# Altium schematic sheet arm.SchDoc (continued)

## Library data of the parts
ARM_JTAG = 20021121-00010C4LF: Conn Unshrouded Header HDR 10 POS 1.27mm Solder ST Top Entry SMD Minitek127® T/R; Manufacturer 1: Amphenol ICC / FCI; Component Kind: Standard; ComponentLink1Description: https://datasheet.ciiva.com/11749/0900766b8118d62a-11749057.pdf?src-supplier=RSComponents; ComponentLink1URL: https://datasheet.ciiva.com/11749/0900766b8118d62a-11749057.pdf?src-supplier=RSComponents; Manufacturer Part Number 1: 20021121-00010C4LF; Pricing 1: 1=0,91000, 10=0,76900, 100=0,69070 (USD); RoHS: No; Stock 1: 0; Supplier 1: Digi-Key; Supplier Part Number 1: 609-3695-1-ND; Library Name: conectors.SchLib
C14 C18-C21 C25-C30 C32 C33 C45 = CAP_CC0805KRX7R8BB104_YAGEO: CAPACITOR, 0805, 100NF, 25V, REEL 4000; Manufacturer 1: Yageo; Dielectric: X7R; Insulation Resistance: 100GR; Material: Ceramic; Tolerance: 10%; Case/Package: 0805; Case Code (Imperial): 0805; Case Code (Metric): 2012; Component Kind: Standard; ComponentLink1Description: https://datasheet.ciiva.com/8034/70765-8034031.pdf?src-supplier=Element14; ComponentLink1URL: https://datasheet.ciiva.com/8034/70765-8034031.pdf?src-supplier=Element14; ComponentLink2Description: https://datasheet.ciiva.com/28450/1489800-28450789.pdf?src-supplier=Element14; ComponentLink2URL: https://datasheet.ciiva.com/28450/1489800-28450789.pdf?src-supplier=Element14; ComponentLink3Description: https://datasheet.ciiva.com/46430/1850775-46430166.pdf?src-supplier=Element14; ComponentLink3URL: https://datasheet.ciiva.com/46430/1850775-46430166.pdf?src-supplier=Element14; ComponentLink4Description: https://datasheet.ciiva.com/145/mlccorderingcode-145008.pdf?src-supplier=Digi-Key; ComponentLink4URL: https://datasheet.ciiva.com/145/mlccorderingcode-145008.pdf?src-supplier=Digi-Key; ComponentLink5Description: https://datasheet.ciiva.com/145/mlccorderingcode-145007.pdf?src-supplier=Digi-Key; ComponentLink5URL: https://datasheet.ciiva.com/145/mlccorderingcode-145007.pdf?src-supplier=Digi-Key; ComponentLink6Description: https://datasheet.ciiva.com/145/mlccorderingcode-145009.pdf?src-supplier=Digi-Key; ComponentLink6URL: https://datasheet.ciiva.com/145/mlccorderingcode-145009.pdf?src-supplier=Digi-Key; ComponentLink7Description: https://datasheet.ciiva.com/8034/1784427-8034030.pdf?src-supplier=Element14; ComponentLink7URL: https://datasheet.ciiva.com/8034/1784427-8034030.pdf?src-supplier=Element14; ComponentLink8Description: https://datasheet.ciiva.com/8034/1784427-8034029.pdf?src-supplier=Element14; ComponentLink8URL: https://datasheet.ciiva.com/8034/1784427-8034029.pdf?src-supplier=Element14; ComponentLink9Description: https://datasheet.ciiva.com/15189/getdatasheetpartid-330244-15189223.pdf?src-supplier=Verical; ComponentLink9URL: https://datasheet.ciiva.com/15189/getdatasheetpartid-330244-15189223.pdf?src-supplier=Verical; ComponentLink10Description: https://datasheet.ciiva.com/16625/upy-gphc-x7r-6-3v-to-50v-8-16625546.pdf?src-supplier=Avnet+Europe; ComponentLink10URL: https://datasheet.ciiva.com/16625/upy-gphc-x7r-6-3v-to-50v-8-16625546.pdf?src-supplier=Avnet+Europe; Depth: 1.25mm; Halogen Free: Halogen Free; Height: 0.85mm; Lead Free: Lead Free; Length: 2mm; Library Name: Cap.SchLib; Manufacturer Part Number 1: CC0805KRX7R8BB104; Max Operating Temperature: 125°C; Min Operating Temperature: -55°C; Mount: Surface Mount; Number of Pins: 2; Package Quantity: 1; Packaging: Tape and Reel; Pricing 1: 1=0,12000, 10=0,08800, 50=0,04980, 100=0,04130, 500=0,02894, 1000=0,02396 (USD); Radiation Hardening: No; REACH SVHC: No SVHC; Resistor Type: Ceramic; RoHS: Yes; RoHS Compliant: Yes; Series: CC; Stock 1: 278639; Supplier 1: Digi-Key; Supplier Part Number 1: 311-1141-1-ND; Termination: SMD/SMT; Thickness: 0.033inch; Voltage Rating (DC): 25V; Width: 0.049inch
C15 C22 C23 C31 = CAP_C0805X150J5GACTU_KEMET: Multilayer Ceramic Capacitors MLCC - SMD/SMT 50volts 15pF 5% C0G; Manufacturer 1: KEMET; Case/Package: 0805; Case Code (Imperial): 0805; Case Code (Metric): 2012; Component Kind: Standard; ComponentLink1Description: http://www.mouser.com/ds/2/212/KEM_C1062_C0G_FT-CAP_SMD-1101746.pdf?src-supplier=Mouser; ComponentLink1URL: http://www.mouser.com/ds/2/212/KEM_C1062_C0G_FT-CAP_SMD-1101746.pdf?src-supplier=Mouser; ComponentLink2Description: http://www.kemet.com/kemet/web/homepage/kechome.nsf/file/KEM_C1062_C0G_FT-CAP_SMD.pdf/$file/KEM_C1062_C0G_FT-CAP_SMD.pdf?src-supplier=Avnet; ComponentLink2URL: http://www.kemet.com/kemet/web/homepage/kechome.nsf/file/KEM_C1062_C0G_FT-CAP_SMD.pdf/$file/KEM_C1062_C0G_FT-CAP_SMD.pdf?src-supplier=Avnet; ComponentLink3Description: https://search.kemet.com/component-edge/download/specsheet/C0805X150J5GACTU.pdf?src-supplier=Digi-Key; ComponentLink3URL: https://search.kemet.com/component-edge/download/specsheet/C0805X150J5GACTU.pdf?src-supplier=Digi-Key; Construction: Flat; Depth: 1.25mm; Dielectric: C0G; Dielectric Material: Ceramic; Features: Soft Termination; Height: 0.78mm; Lead Free: Lead Free; Length: 0.083inch; Library Name: Cap.SchLib; Manufacturer Part Number 1: C0805X150J5GACTU; Max Operating Temperature: 125°C; Min Operating Temperature: -55°C; Mount: Surface Mount; Package Quantity: 4000; Packaging: Tape and Reel; Pricing 1: 1=0,33000, 10=0,23100, 50=0,16160, 100=0,13860, 500=0,10396, 1000=0,08778 (USD); Radiation Hardening: No; RoHS: Yes; RoHS Compliant: Yes; Series: FT-CAP; Stock 1: 2348; Supplier 1: Digi-Key; Supplier Part Number 1: 399-7428-1-ND; Termination: SMD/SMT; Thickness: 0.035inch; Tolerance: 5%; Voltage Rating (DC): 50V; Width: 1.25mm
C16 C17 C24 C42 = CAP_CL21A106KOCL3RC: CAP CER 10UF 16V X5R 0805; Manufacturer 1: Samsung; Tolerance: 10%; Case/Package: 0805; Case Code (Imperial): 0805; Case Code (Metric): 2012; Component Kind: Standard; ComponentLink1Description: https://datasheet.ciiva.com/25796/cl21a106kocl3rc-25796224.pdf?src-supplier=Digi-Key; ComponentLink1URL: https://datasheet.ciiva.com/25796/cl21a106kocl3rc-25796224.pdf?src-supplier=Digi-Key; Length: 0.079inch; Library Name: Cap.SchLib; Manufacturer Part Number 1: CL21A106KOCL3RC; Max Operating Temperature: 85°C; Min Operating Temperature: -55°C; Mount: Surface Mount; Package Quantity: 1; Packaging: Tape and Reel; RoHS: Yes; RoHS Compliant: Yes; Series: CL; Stock 1: 0; Supplier 1: Digi-Key; Supplier Part Number 1: 1276-2398-2-ND; Thickness: 0.037inch; Width: 0.049inch
C34 C35 = CAP_CC0805KKX7R7BB105_YAGEO: YAGEO   CC0805KKX7R7BB105   CERAMIC CAPACITOR, 1UF, 16V, X7R, 10%, 0805; Case/Package: 0805; Case Code (Imperial): 0805; Case Code (Metric): 2012; Component Kind: Standard; ComponentLink1Description: https://datasheet.ciiva.com/144/mlccorderingcode-144902.pdf?src-supplier=Digi-Key; ComponentLink1URL: https://datasheet.ciiva.com/144/mlccorderingcode-144902.pdf?src-supplier=Digi-Key; ComponentLink2Description: https://datasheet.ciiva.com/144/mlccorderingcode-144903.pdf?src-supplier=Digi-Key; ComponentLink2URL: https://datasheet.ciiva.com/144/mlccorderingcode-144903.pdf?src-supplier=Digi-Key; ComponentLink3Description: https://datasheet.ciiva.com/144/mlccorderingcode-144904.pdf?src-supplier=Digi-Key; ComponentLink3URL: https://datasheet.ciiva.com/144/mlccorderingcode-144904.pdf?src-supplier=Digi-Key; ComponentLink4Description: https://datasheet.ciiva.com/11464/upy-gphc-x7r-6-3v-to-50v-8-11464750.pdf?src-supplier=Avnet; ComponentLink4URL: https://datasheet.ciiva.com/11464/upy-gphc-x7r-6-3v-to-50v-8-11464750.pdf?src-supplier=Avnet; ComponentLink5Description: https://datasheet.ciiva.com/13173/upy-gp-np0-16v-to-50v-8-3714-13173254.pdf?src-supplier=Mouser; ComponentLink5URL: https://datasheet.ciiva.com/13173/upy-gp-np0-16v-to-50v-8-3714-13173254.pdf?src-supplier=Mouser; ComponentLink6Description: https://datasheet.ciiva.com/7978/1489800-7978201.pdf?src-supplier=Element14; ComponentLink6URL: https://datasheet.ciiva.com/7978/1489800-7978201.pdf?src-supplier=Element14; ComponentLink7Description: https://datasheet.ciiva.com/28450/1489800-28450750.pdf?src-supplier=Element14; ComponentLink7URL: https://datasheet.ciiva.com/28450/1489800-28450750.pdf?src-supplier=Element14; ComponentLink8Description: https://datasheet.ciiva.com/15189/getdatasheetpartid-251802-15189206.pdf?src-supplier=Verical; ComponentLink8URL: https://datasheet.ciiva.com/15189/getdatasheetpartid-251802-15189206.pdf?src-supplier=Verical; Depth: 1.25mm; Dielectric: X7R; Halogen Free: Halogen Free; Lead Free: Lead Free; Length: 2mm; Library Name: Cap.SchLib; Manufacturer 1: Yageo; Manufacturer Part Number 1: CC0805KKX7R7BB105; Max Operating Temperature: 125°C; Min Operating Temperature: -55°C; Mount: Surface Mount; Package Quantity: 1; Packaging: Tape and Reel; Pricing 1: 1=0,16000, 10=0,11700, 50=0,06640, 100=0,05500, 500=0,03858, 1000=0,03194 (USD); Radiation Hardening: No; REACH SVHC: No SVHC; RoHS: Yes; RoHS Compliant: Yes; Series: CC; Stock 1: 516899; Supplier 1: Digi-Key; Supplier Part Number 1: 311-1365-1-ND; Termination: SMD/SMT; Thickness: 0.049inch; Tolerance: 10%; Voltage Rating (DC): 16V; Width: 0.049inch
D3 = 150080RS75000: SMD LED red 2.4 V 0805, 150080RS75000, Würth Elektronik; Manufacturer 1: Wurth Electronics; Dominant Wavelength: 625nm; Forward Current: 30mA; Forward Voltage: 2V; Lens Style: Clear,Rectangular; Luminous Intensity: 150mcd; Test Current: 20mA; Viewing Angle: 140°; Case/Package: 0805; Case Code (Imperial): 0805; Case Code (Metric): 2012; Color: Red; Component Kind: Standard; ComponentLink1Description: https://datasheet.ciiva.com/22656/150080rs75000-22656961.pdf?src-supplier=Element14; ComponentLink1URL: https://datasheet.ciiva.com/22656/150080rs75000-22656961.pdf?src-supplier=Element14; ComponentLink2Description: https://datasheet.ciiva.com/29279/759435-da-01-en-chipled-0805-w-kl-rot-150080rs75000-29279032.pdf?src-supplier=Conrad; ComponentLink2URL: https://datasheet.ciiva.com/29279/759435-da-01-en-chipled-0805-w-kl-rot-150080rs75000-29279032.pdf?src-supplier=Conrad; Height: 0.7mm; Length: 2mm; Library Name: diode.SchLib; Manufacturer Part Number 1: 150080RS75000; Max Operating Temperature: 85°C; Min Operating Temperature: -40°C; Mount: Surface Mount; Package Quantity: 4000; Packaging: Tape and Reel; Pricing 1: 1=0,18000, 50=0,17100, 100=0,14700, 500=0,12700 (USD); REACH SVHC: Unknown; RoHS: Yes; RoHS Compliant: Yes; Stock 1: 26828; Supplier 1: Digi-Key; Supplier Part Number 1: 732-4984-1-ND; Wavelength: 625nm; Width: 1.25mm
D4 D5 = DB2J20900L: PANASONIC - DB2J20900L - Schottky Rectifier, 20 V, 500 mA, Single, SOD-323F, 2 Pins, 500 mV; Manufacturer 1: Panasonic SSG; Average Rectified Current: 500mA; Forward Voltage: 500mV; Max Forward Surge Current (Ifsm): 3A; Max Repetitive Reverse Voltage (Vrrm): 20V; Max Reverse Current: 30uA; Reverse Recovery Time: 2.4ns; Component Kind: Standard; ComponentLink1Description: https://datasheet.ciiva.com/7964/db2j209-bed-7964194.pdf?src-supplier=Element14; ComponentLink1URL: https://datasheet.ciiva.com/7964/db2j209-bed-7964194.pdf?src-supplier=Element14; ComponentLink2Description: https://datasheet.ciiva.com/22650/db2j209-bed-22650026.pdf?src-supplier=Farnell; ComponentLink2URL: https://datasheet.ciiva.com/22650/db2j209-bed-22650026.pdf?src-supplier=Farnell; ComponentLink3Description: https://datasheet.ciiva.com/22659/1912053-22659242.pdf?src-supplier=Element14; ComponentLink3URL: https://datasheet.ciiva.com/22659/1912053-22659242.pdf?src-supplier=Element14; Contact Plating: Tin; Element Configuration: Single; Forward Current: 500mA; Height: 0.7mm; Lead Free: Lead Free; Length: 1.7mm; Library Name: diode.SchLib; Manufacturer Part Number 1: DB2J20900L; Max Operating Temperature: 125°C; Max Reverse Voltage (DC): 20V; Min Operating Temperature: -55°C; Mount: Surface Mount; Number of Pins: 2; Package Quantity: 1; Packaging: Tape and Reel; Peak Non-Repetitive Surge Current: 3A; Pricing 1: 1=0,17400, 100=0,16600, 200=0,15100, 400=0,13600, 1000=0,12100 (USD); REACH SVHC: Unknown; RoHS: Yes; RoHS Compliant: Yes; Stock 1: 0; Supplier 1: Allied Electronics; Supplier Part Number 1: 70358466; Width: 1.25mm
D6 = 150080VS75000: LED GREEN CLEAR 0805 SMD; Manufacturer 1: Wurth Electronics; Dominant Wavelength: 570nm; Forward Current: 30mA; Forward Voltage: 2V; Lens Style: Clear,Rectangular; Luminous Intensity: 40mcd; Test Current: 20mA; Viewing Angle: 140°; Case/Package: 0805; Case Code (Imperial): 0805; Case Code (Metric): 2012; Color: Green; Component Kind: Standard; ComponentLink1Description: https://datasheet.ciiva.com/22656/150080vs75000-22656966.pdf?src-supplier=Element14; ComponentLink1URL: https://datasheet.ciiva.com/22656/150080vs75000-22656966.pdf?src-supplier=Element14; ComponentLink2Description: https://datasheet.ciiva.com/29279/759437-da-01-en-chipled-0805-w-kl-h-gruen-150080vs7500-29279047.pdf?src-supplier=Conrad; ComponentLink2URL: https://datasheet.ciiva.com/29279/759437-da-01-en-chipled-0805-w-kl-h-gruen-150080vs7500-29279047.pdf?src-supplier=Conrad; ComponentLink3Description: https://datasheet.ciiva.com/29563/150080vs75000-29563018.pdf?src-supplier=Digi-Key; ComponentLink3URL: https://datasheet.ciiva.com/29563/150080vs75000-29563018.pdf?src-supplier=Digi-Key; Height: 0.7mm; Length: 2mm; Library Name: diode.SchLib; Manufacturer Part Number 1: 150080VS75000; Max Operating Temperature: 85°C; Min Operating Temperature: -40°C; Mount: Surface Mount; Package Quantity: 4000; Packaging: Tape and Reel; Pricing 1: 500=0,09600, 1000=0,09200, 2000=0,08700, 4000=0,08300 (GBP); REACH SVHC: Unknown; RoHS: Yes; Stock 1: 13900; Supplier 1: RSComponents; Supplier Part Number 1: 8154237P; Wavelength: 570nm; Width: 1.25mm
L1 = CM453232-100KL: Ind General Purpose Chip Wirewound 10uH 10% 2.52MHz 50Q-Factor Ferrite 250mA 1812 T/R; Manufacturer 1: Bourns; Current: 160mA; DC Resistance (DCR): 1.6R; Q Factor: 50; Self Resonant Frequency: 20MHz; Shielding: Unshielded; Case/Package: 1812; Case Code (Imperial): 1812; Case Code (Metric): 4532; Component Kind: Standard; ComponentLink1Description: https://datasheet.ciiva.com/27049/774461-27049974.pdf?src-supplier=Jameco; ComponentLink1URL: https://datasheet.ciiva.com/27049/774461-27049974.pdf?src-supplier=Jameco; ComponentLink2Description: https://datasheet.ciiva.com/11799/0900766b81218b74-11799714.pdf?src-supplier=RSComponents; ComponentLink2URL: https://datasheet.ciiva.com/11799/0900766b81218b74-11799714.pdf?src-supplier=RSComponents; ComponentLink3Description: https://datasheet.ciiva.com/29348/447189-da-01-en-induktivitaet-cm453232-100kl-10uh-250ma-29348045.pdf?src-supplier=Conrad; ComponentLink3URL: https://datasheet.ciiva.com/29348/447189-da-01-en-induktivitaet-cm453232-100kl-10uh-250ma-29348045.pdf?src-supplier=Conrad; ComponentLink4Description: https://datasheet.ciiva.com/3081/cm-3081727.pdf?src-supplier=Avnet; ComponentLink4URL: https://datasheet.ciiva.com/3081/cm-3081727.pdf?src-supplier=Avnet; ComponentLink5Description: https://datasheet.ciiva.com/6689/cm-6689143.pdf?src-supplier=Digi-Key; ComponentLink5URL: https://datasheet.ciiva.com/6689/cm-6689143.pdf?src-supplier=Digi-Key; ComponentLink6Description: https://datasheet.ciiva.com/6689/cm-6689144.pdf?src-supplier=Digi-Key; ComponentLink6URL: https://datasheet.ciiva.com/6689/cm-6689144.pdf?src-supplier=Digi-Key; ComponentLink7Description: https://datasheet.ciiva.com/6689/cm-6689145.pdf?src-supplier=Digi-Key; ComponentLink7URL: https://datasheet.ciiva.com/6689/cm-6689145.pdf?src-supplier=Digi-Key; ComponentLink8Description: https://datasheet.ciiva.com/4978/cm-4978555.pdf?src-supplier=Mouser; ComponentLink8URL: https://datasheet.ciiva.com/4978/cm-4978555.pdf?src-supplier=Mouser; ComponentLink9Description: https://datasheet.ciiva.com/25903/cm-25903528.pdf?src-supplier=Avnet+Europe; ComponentLink9URL: https://datasheet.ciiva.com/25903/cm-25903528.pdf?src-supplier=Avnet+Europe; ComponentLink10Description: https://datasheet.ciiva.com/14124/wfcm-dat-e-14124666.pdf?src-supplier=Distrelec; ComponentLink10URL: https://datasheet.ciiva.com/14124/wfcm-dat-e-14124666.pdf?src-supplier=Distrelec; ComponentLink11Description: https://datasheet.ciiva.com/15225/getdatasheetpartid-128072-15225413.pdf?src-supplier=Verical; ComponentLink11URL: https://datasheet.ciiva.com/15225/getdatasheetpartid-128072-15225413.pdf?src-supplier=Verical; ComponentLink12Description: https://datasheet.ciiva.com/7839/1481743-7839005.pdf?src-supplier=Element14; ComponentLink12URL: https://datasheet.ciiva.com/7839/1481743-7839005.pdf?src-supplier=Element14; ComponentLink13Description: https://datasheet.ciiva.com/7839/1481743-7839006.pdf?src-supplier=Element14; ComponentLink13URL: https://datasheet.ciiva.com/7839/1481743-7839006.pdf?src-supplier=Element14; Contact Plating: Tin; Core Material: Ferrite; DC Current: 250mA; Depth: 3.4mm; Height: 3mm; Height - Seated (Max): 0.134inch; Inductance: 10uH; Inductance Tolerance: 10%; Length: 4.8mm; Manufacturer Part Number 1: CM453232-100KL; Max DC Current: 250mA; Max Operating Temperature: 100°C; Military Standard: Not; Min Operating Temperature: -40°C; Mount: Surface Mount; Number of Pins: 2; Package Quantity: 500; Packaging: Tape and Reel; Pricing 1: 1=0,43000, 10=0,38100, 25=0,33320, 50=0,28540, 100=0,26170, 250=0,23788 (USD); Radiation Hardening: No; REACH SVHC: No SVHC; Resistor Type: Wirewound; RoHS: Yes; RoHS Compliant: Yes; Series: CM453232; Series Resistance: 1.6R; Stock 1: 1374; Supplier 1: Digi-Key; Supplier Part Number 1: CM453232-100KLCT-ND; Termination: SMD/SMT; Test Frequency: 2.52MHz; Tolerance: 10%; Width: 0.126inch; Library Name: inductores.SchLib
Q1 Q2 = MMBT2222ALT1G: Trans GP BJT NPN 40V 0.6A 3-Pin SOT-23 T/R; Manufacturer 1: ON Semiconductor; Collector-emitter Voltage-Max: 1V; Collector Base Voltage (VCBO): 75V; Current Rating: 600mA; Element Configuration: Single; Emitter Base Voltage (VEBO): 6V; Frequency: 300MHz; hFE Min: 100; Max Power Dissipation: 300mW; Number of Elements: 1; Type: General Purpose; Case/Package: SOT-23-3; Collector Emitter Breakdown Voltage: 40V; Collector Emitter Saturation Voltage: 1V; Collector Emitter Voltage (VCEO): 40V; Component Kind: Standard; ComponentLink1Description: https://datasheet.ciiva.com/16199/mmbt2222lt1-d-16199072.pdf?src-supplier=Future+Electronics; ComponentLink1URL: https://datasheet.ciiva.com/16199/mmbt2222lt1-d-16199072.pdf?src-supplier=Future+Electronics; ComponentLink2Description: https://datasheet.ciiva.com/15139/getdatasheetpartid-82691-15139077.pdf?src-supplier=Verical; ComponentLink2URL: https://datasheet.ciiva.com/15139/getdatasheetpartid-82691-15139077.pdf?src-supplier=Verical; ComponentLink3Description: https://datasheet.ciiva.com/976/mmbt2222lt1-d-976344.pdf?src-supplier=Digi-Key; ComponentLink3URL: https://datasheet.ciiva.com/976/mmbt2222lt1-d-976344.pdf?src-supplier=Digi-Key; ComponentLink4Description: https://datasheet.ciiva.com/976/mmbt2222lt1-d-976345.pdf?src-supplier=Digi-Key; ComponentLink4URL: https://datasheet.ciiva.com/976/mmbt2222lt1-d-976345.pdf?src-supplier=Digi-Key; ComponentLink5Description: https://datasheet.ciiva.com/976/mmbt2222lt1-d-976346.pdf?src-supplier=Digi-Key; ComponentLink5URL: https://datasheet.ciiva.com/976/mmbt2222lt1-d-976346.pdf?src-supplier=Digi-Key; ComponentLink6Description: https://datasheet.ciiva.com/11201/mmbt2222lt1-d-11201869.pdf?src-supplier=Avnet; ComponentLink6URL: https://datasheet.ciiva.com/11201/mmbt2222lt1-d-11201869.pdf?src-supplier=Avnet; ComponentLink7Description: https://datasheet.ciiva.com/25998/mmbt2222lt1-d-25998815.pdf?src-supplier=Avnet+Europe; ComponentLink7URL: https://datasheet.ciiva.com/25998/mmbt2222lt1-d-25998815.pdf?src-supplier=Avnet+Europe; ComponentLink8Description: https://datasheet.ciiva.com/7972/mmbt2222lt1-d-7972057.pdf?src-supplier=Element14; ComponentLink8URL: https://datasheet.ciiva.com/7972/mmbt2222lt1-d-7972057.pdf?src-supplier=Element14; ComponentLink9Description: https://datasheet.ciiva.com/7972/mmbt2222lt1-d-7972058.pdf?src-supplier=Element14; ComponentLink9URL: https://datasheet.ciiva.com/7972/mmbt2222lt1-d-7972058.pdf?src-supplier=Element14; ComponentLink10Description: https://datasheet.ciiva.com/14546/70099528-14546715.pdf?src-supplier=Allied+Electronics; ComponentLink10URL: https://datasheet.ciiva.com/14546/70099528-14546715.pdf?src-supplier=Allied+Electronics; Contact Plating: Tin,Matte; Gain Bandwidth Product: 300MHz; Height: 0.94mm; Lead Free: Lead Free; Length: 2.9mm; Library Name: others.SchLib; Manufacturer Part Number 1: MMBT2222ALT1G; Max Breakdown Voltage: 40V; Max Collector Current: 600mA; Max Frequency: 300MHz; Max Operating Temperature: 150°C; Min Operating Temperature: -55°C; Number of Pins: 3; Package Quantity: 1; Packaging: Tape and Reel; Polarity: NPN; Power Dissipation: 300mW; Pricing 1: 1=0,16000, 10=0,14800, 100=0,08030, 500=0,04944, 1000=0,03371 (USD); Radiation Hardening: No; REACH SVHC: No SVHC; RoHS: Yes; Series: -; Stock 1: 0; Supplier 1: Digi-Key; Supplier Part Number 1: MMBT2222ALT1GOSCT-ND; Transition Frequency: 300MHz; Voltage Rating (DC): 40V; Width: 1.3mm
R25 R33 = RC0805FR-07130RL: Res Thick Film smd 0805 130 Ohm 1% 0.125W(; Manufacturer 1: Yageo; Max Power Dissipation: 125mW; Temperature Coefficient: 100ppm/°C; Voltage Rating: 150V; Case/Package: 0805; Case Code (Imperial): 0805; Case Code (Metric): 2012; Component Kind: Standard; ComponentLink1Description: https://datasheet.ciiva.com/11808/0900766b8106beab-11808374.pdf?src-supplier=RSComponents; ComponentLink1URL: https://datasheet.ciiva.com/11808/0900766b8106beab-11808374.pdf?src-supplier=RSComponents; ComponentLink2Description: https://datasheet.ciiva.com/2457/pyu-r-int-thick-7-2457546.pdf?src-supplier=Digi-Key; ComponentLink2URL: https://datasheet.ciiva.com/2457/pyu-r-int-thick-7-2457546.pdf?src-supplier=Digi-Key; ComponentLink3Description: https://datasheet.ciiva.com/2457/pyu-r-int-thick-7-2457547.pdf?src-supplier=Digi-Key; ComponentLink3URL: https://datasheet.ciiva.com/2457/pyu-r-int-thick-7-2457547.pdf?src-supplier=Digi-Key; ComponentLink4Description: https://datasheet.ciiva.com/2457/pyu-r-int-thick-7-2457545.pdf?src-supplier=Digi-Key; ComponentLink4URL: https://datasheet.ciiva.com/2457/pyu-r-int-thick-7-2457545.pdf?src-supplier=Digi-Key; ComponentLink5Description: https://datasheet.ciiva.com/15189/getdatasheetpartid-326400-15189899.pdf?src-supplier=Verical; ComponentLink5URL: https://datasheet.ciiva.com/15189/getdatasheetpartid-326400-15189899.pdf?src-supplier=Verical; ComponentLink6Description: https://datasheet.ciiva.com/16746/rc0805-16746879.pdf?src-supplier=Future+Electronics; ComponentLink6URL: https://datasheet.ciiva.com/16746/rc0805-16746879.pdf?src-supplier=Future+Electronics; Construction: Rectangular; Depth: 1.25mm; Features: Moisture Resistant; Height: 0.5mm; Lead Free: Lead Free; Length: 2mm; Library Name: res.SchLib; Manufacturer Part Number 1: RC0805FR-07130RL; Max Operating Temperature: 155°C; Military Standard: Not; Min Operating Temperature: -55°C; Mount: Surface Mount; Number of Terminations: 2; Package Quantity: 1; Packaging: Tape and Reel; Power Rating: 125mW; Pricing 1: 1=0,10000, 10=0,04200, 100=0,01690, 1000=0,00759, 2500=0,00659 (USD); Resistor Type: Thick Film; RoHS: Yes; RoHS Compliant: Yes; Series: RC; Size Code: 0805; Stock 1: 289647; Supplier 1: Digi-Key; Supplier Part Number 1: 311-130CRCT-ND; Technology: THICK FILM; Termination: SMD/SMT; Tolerance: 1%; Voltage Rating (DC): 150V; Width: 0.049inch
R26-R29 R47 = AC0805JR-07100KL: Thick Film Resistors - SMD 0805  100K ohm 5% 1/8W; Manufacturer 1: Yageo; Max Power Dissipation: 125mW; Temperature Coefficient: 100ppm/°C; Voltage Rating: 150V; Case/Package: 0805; Case Code (Imperial): 0805; Case Code (Metric): 2012; Component Kind: Standard; ComponentLink1Description: https://datasheet.ciiva.com/12576/pyu-ac-51-rohs-l-2-12576604.pdf?src-supplier=Mouser; ComponentLink1URL: https://datasheet.ciiva.com/12576/pyu-ac-51-rohs-l-2-12576604.pdf?src-supplier=Mouser; Features: Moisture Resistant,Automotive AEC-Q200; Height: 0.024inch; Length: 0.079inch; Library Name: res.SchLib; Manufacturer Part Number 1: AC0805JR-07100KL; Max Operating Temperature: 155°C; Min Operating Temperature: -55°C; Number of Terminations: 2; Packaging: Tape and Reel; Power Rating: 125mW; Pricing 1: 1=0,10000, 10=0,04300, 100=0,01730, 1000=0,00777, 2500=0,00674 (USD); Resistor Type: Thick Film; RoHS: Yes; RoHS Compliant: Yes; Series: AC; Stock 1: 23; Supplier 1: Digi-Key; Supplier Part Number 1: YAG3756CT-ND; Termination: SMD/SMT; Tolerance: 5%; Weight: 0.000194oz; Width: 0.049inch
R30-R32 R34 R52-R55 = RC0805JR-0710KL: Res Thick Film smd 0805 10K Ohm 5% 1/8W; Manufacturer 1: Yageo; Max Power Dissipation: 125mW; Temperature Coefficient: 100ppm/°C; Voltage Rating: 150V; Case/Package: 0805; Case Code (Imperial): 0805; Case Code (Metric): 2012; Component Kind: Standard; ComponentLink1Description: https://datasheet.ciiva.com/7941/444164-7941870.pdf?src-supplier=Element14; ComponentLink1URL: https://datasheet.ciiva.com/7941/444164-7941870.pdf?src-supplier=Element14; ComponentLink2Description: https://datasheet.ciiva.com/7941/444164-7941871.pdf?src-supplier=Element14; ComponentLink2URL: https://datasheet.ciiva.com/7941/444164-7941871.pdf?src-supplier=Element14; ComponentLink3Description: https://datasheet.ciiva.com/7983/444164-7983765.pdf?src-supplier=Element14; ComponentLink3URL: https://datasheet.ciiva.com/7983/444164-7983765.pdf?src-supplier=Element14; Construction: Rectangular; Contact Plating: Tin,Matte; Depth: 1.25mm; Features: Moisture Resistant; Height: 0.5mm; Lead Free: Lead Free; Length: 2mm; Library Name: res.SchLib; Manufacturer Part Number 1: RC0805JR-0710KL; Max Operating Temperature: 155°C; Military Standard: Not; Min Operating Temperature: -55°C; Number of Terminations: 2; Packaging: Tape and Reel; Power Rating: 125mW; Pricing 1: 1=0,10000, 10=0,03600, 100=0,01450, 1000=0,00652, 2500=0,00566 (USD); Radiation Hardening: No; REACH SVHC: Unknown; Resistance Tolerance: 5%; Resistor Type: Thick Film; RoHS: Yes; RoHS Compliant: Yes; Series: RC; Size Code: 0805; Stock 1: 2124400; Supplier 1: Digi-Key; Supplier Part Number 1: 311-10KARCT-ND; Termination: SMD/SMT; Tolerance: 5%; Width: 1.25mm
R35 = RC1206FR-0710KL: Res Thick Film smd 1206 10K Ohm 1% 0.25W(1/4W); Manufacturer 1: Yageo; Max Power Dissipation: 250mW; Temperature Coefficient: 100ppm/°C; Voltage Rating: 200V; Case/Package: 1206; Case Code (Imperial): 1206; Case Code (Metric): 3216; Component Kind: Standard; ComponentLink1Description: https://datasheet.ciiva.com/7857/1692832-7857244.pdf?src-supplier=Element14; ComponentLink1URL: https://datasheet.ciiva.com/7857/1692832-7857244.pdf?src-supplier=Element14; ComponentLink2Description: https://datasheet.ciiva.com/7857/1692832-7857245.pdf?src-supplier=Element14; ComponentLink2URL: https://datasheet.ciiva.com/7857/1692832-7857245.pdf?src-supplier=Element14; ComponentLink3Description: https://datasheet.ciiva.com/46445/444357-46445703.pdf?src-supplier=Element14; ComponentLink3URL: https://datasheet.ciiva.com/46445/444357-46445703.pdf?src-supplier=Element14; Construction: Rectangular; Depth: 1.6mm; Features: Moisture Resistant; Height: 0.55mm; Lead Free: Lead Free; Length: 3.1mm; Library Name: res.SchLib; Manufacturer Part Number 1: RC1206FR-0710KL; Max Operating Temperature: 155°C; Military Standard: Not; Min Operating Temperature: -55°C; Number of Terminations: 2; Package Quantity: 5000; Packaging: Tape and Reel; Power Rating: 250mW; Pricing 1: 1=0,10000, 10=0,07400, 100=0,03010, 1000=0,01353, 2500=0,01174 (USD); REACH SVHC: Unknown; Resistance Tolerance: 1%; Resistor Type: Thick Film; RoHS: Yes; RoHS Compliant: Yes; Series: RC; Size Code: 1206; Stock 1: 845388; Supplier 1: Digi-Key; Supplier Part Number 1: 311-10.0KFRCT-ND; Termination: SMD/SMT; Tolerance: 1%; Width: 1.6mm
R36-R39 = RC1206JR-0768KL: Thick Film Resistors smd 1206(3216) 68K OHM 5% 250mW; Manufacturer 1: Yageo; Temperature Coefficient: 100ppm/°C; Voltage Rating: 200V; Case/Package: 1206; Case Code (Imperial): 1206; Case Code (Metric): 3216; Component Kind: Standard; ComponentLink1Description: https://datasheet.ciiva.com/7857/444357-7857527.pdf?src-supplier=Element14; ComponentLink1URL: https://datasheet.ciiva.com/7857/444357-7857527.pdf?src-supplier=Element14; ComponentLink2Description: https://datasheet.ciiva.com/7857/444357-7857528.pdf?src-supplier=Element14; ComponentLink2URL: https://datasheet.ciiva.com/7857/444357-7857528.pdf?src-supplier=Element14; Construction: Rectangular; Depth: 1.6mm; Features: Moisture Resistant; Lead Free: Lead Free; Length: 3.1mm; Library Name: res.SchLib; Manufacturer Part Number 1: RC1206JR-0768KL; Max Operating Temperature: 155°C; Max Power Dissipation: 250mW; Military Standard: Not; Min Operating Temperature: -55°C; Number of Terminations: 2; Packaging: Tape and Reel; Power Rating: 250mW; Pricing 1: 1=0,10000, 10=0,06300, 100=0,02580, 1000=0,01158, 2500=0,01005 (USD); REACH SVHC: No SVHC; Resistance Tolerance: 5%; Resistor Type: Thick Film; RoHS: Yes; RoHS Compliant: Yes; Series: RC; Size Code: 1206; Stock 1: 38664; Supplier 1: Digi-Key; Supplier Part Number 1: 311-68KERCT-ND; Termination: SMD/SMT; Tolerance: 5%
R40-R46 = RC1206JR-07100RL: Thick Film Resistors SMD 1206 (3264)  100 OHM 5% 250mW; Manufacturer 1: Yageo; Temperature Coefficient: 100ppm/°C; Voltage Rating: 200V; Case/Package: 1206; Case Code (Imperial): 1206; Case Code (Metric): 3216; Component Kind: Standard; ComponentLink1Description: https://datasheet.ciiva.com/29181/402427-da-01-en-smd-widerstand-chip1206-100-ohm-29181432.pdf?src-supplier=Conrad; ComponentLink1URL: https://datasheet.ciiva.com/29181/402427-da-01-en-smd-widerstand-chip1206-100-ohm-29181432.pdf?src-supplier=Conrad; ComponentLink2Description: https://datasheet.ciiva.com/2458/pyu-rc1206-51-rohs-l-4-2458710.pdf?src-supplier=Digi-Key; ComponentLink2URL: https://datasheet.ciiva.com/2458/pyu-rc1206-51-rohs-l-4-2458710.pdf?src-supplier=Digi-Key; ComponentLink3Description: https://datasheet.ciiva.com/2458/pyu-rc1206-51-rohs-l-4-2458711.pdf?src-supplier=Digi-Key; ComponentLink3URL: https://datasheet.ciiva.com/2458/pyu-rc1206-51-rohs-l-4-2458711.pdf?src-supplier=Digi-Key; ComponentLink4Description: https://datasheet.ciiva.com/2458/pyu-rc1206-51-rohs-l-4-2458712.pdf?src-supplier=Digi-Key; ComponentLink4URL: https://datasheet.ciiva.com/2458/pyu-rc1206-51-rohs-l-4-2458712.pdf?src-supplier=Digi-Key; ComponentLink5Description: https://datasheet.ciiva.com/16772/pyu-rc1206-51-rohs-l-4-16772235.pdf?src-supplier=Future+Electronics; ComponentLink5URL: https://datasheet.ciiva.com/16772/pyu-rc1206-51-rohs-l-4-16772235.pdf?src-supplier=Future+Electronics; Construction: Rectangular; Depth: 1.6mm; Height: 0.026inch; Lead Free: Lead Free; Length: 0.122inch; Library Name: res.SchLib; Manufacturer Part Number 1: RC1206JR-07100RL; Max Operating Temperature: 155°C; Max Power Dissipation: 250mW; Military Standard: Not; Min Operating Temperature: -55°C; Number of Terminations: 2; Package Quantity: 5000; Packaging: Tape and Reel; Power Rating: 250mW; Pricing 1: 1=0,10000, 10=0,06300, 100=0,02580, 1000=0,01158, 2500=0,01005 (USD); REACH SVHC: Unknown; Resistance Tolerance: 5%; Resistor Type: Thick Film; RoHS: Yes; RoHS Compliant: Yes; Series: RC1206; Size Code: 1206; Stock 1: 1229853; Supplier 1: Digi-Key; Supplier Part Number 1: 311-100ERCT-ND; Termination: SMD/SMT; Tolerance: 5%; Width: 0.063inch
R48 = RC1206JR-07180KL: RES SMD 1206 180K OHM 5% 1/4W; Manufacturer 1: Yageo; Max Power Dissipation: 250mW; Temperature Coefficient: 100ppm/°C; Voltage Rating: 200V; Case/Package: 1206; Case Code (Imperial): 1206; Case Code (Metric): 3216; Component Kind: Standard; ComponentLink1Description: https://datasheet.ciiva.com/29181/402818-da-01-en-smd-widerstand-chip1206-180-kohm-29181400.pdf?src-supplier=Conrad; ComponentLink1URL: https://datasheet.ciiva.com/29181/402818-da-01-en-smd-widerstand-chip1206-180-kohm-29181400.pdf?src-supplier=Conrad; ComponentLink2Description: https://datasheet.ciiva.com/2458/pyu-r-int-thick-7-2458890.pdf?src-supplier=Digi-Key; ComponentLink2URL: https://datasheet.ciiva.com/2458/pyu-r-int-thick-7-2458890.pdf?src-supplier=Digi-Key; ComponentLink3Description: https://datasheet.ciiva.com/2458/pyu-r-int-thick-7-2458891.pdf?src-supplier=Digi-Key; ComponentLink3URL: https://datasheet.ciiva.com/2458/pyu-r-int-thick-7-2458891.pdf?src-supplier=Digi-Key; ComponentLink4Description: https://datasheet.ciiva.com/2458/pyu-r-int-thick-7-2458892.pdf?src-supplier=Digi-Key; ComponentLink4URL: https://datasheet.ciiva.com/2458/pyu-r-int-thick-7-2458892.pdf?src-supplier=Digi-Key; ComponentLink5Description: https://datasheet.ciiva.com/17053/pyu-rc1206-51-rohs-l-4-17053351.pdf?src-supplier=Future+Electronics; ComponentLink5URL: https://datasheet.ciiva.com/17053/pyu-rc1206-51-rohs-l-4-17053351.pdf?src-supplier=Future+Electronics; Construction: Rectangular; Depth: 1.6mm; Features: Moisture Resistant; Height: 0.026inch; Lead Free: Lead Free; Length: 3.1mm; Library Name: res.SchLib; Manufacturer Part Number 1: RC1206JR-07180KL; Max Operating Temperature: 155°C; Military Standard: Not; Min Operating Temperature: -55°C; Number of Terminations: 2; Package Quantity: 1; Packaging: Tape and Reel; Power Rating: 250mW; Pricing 1: 10=0,03100, 25=0,02500, 50=0,01800, 100=0,01100 (USD); Resistor Type: Thick Film; RoHS: Yes; RoHS Compliant: Yes; Series: RC; Size Code: 1206; Stock 1: 23350; Supplier 1: Newark; Supplier Part Number 1: 82AH8580; Termination: SMD/SMT; Tolerance: 5%; Voltage Rating (DC): 200V; Width: 1.6mm
R49 R50 = RC0805JR-0722RL: Res Thick Film smd  0805 22 Ohm 5% 1/8W; Manufacturer 1: Yageo; Max Power Dissipation: 125mW; Temperature Coefficient: 100ppm/°C; Voltage Rating: 150V; Case/Package: 0805; Case Code (Imperial): 0805; Case Code (Metric): 2012; Component Kind: Standard; ComponentLink1Description: http://www.mouser.com/ds/2/447/Yageo_08112016_RC0805-968729.pdf?src-supplier=Mouser; ComponentLink1URL: http://www.mouser.com/ds/2/447/Yageo_08112016_RC0805-968729.pdf?src-supplier=Mouser; ComponentLink2Description: http://www.farnell.com/datasheets/2167052.pdf?src-supplier=Farnell; ComponentLink2URL: http://www.farnell.com/datasheets/2167052.pdf?src-supplier=Farnell; ComponentLink3Description: http://components.arrow.com/part/dataview/0323_00047573?src-supplier=Arrow+Europe; ComponentLink3URL: http://components.arrow.com/part/dataview/0323_00047573?src-supplier=Arrow+Europe; ComponentLink4Description: http://www.farnell.com/datasheets/444164.pdf?src-supplier=Farnell; ComponentLink4URL: http://www.farnell.com/datasheets/444164.pdf?src-supplier=Farnell; ComponentLink5Description: http://www.yageo.com/documents/recent/PYu-RC_Group_51_RoHS_L_10.pdf?src-supplier=Digi-Key; ComponentLink5URL: http://www.yageo.com/documents/recent/PYu-RC_Group_51_RoHS_L_10.pdf?src-supplier=Digi-Key; ComponentLink6Description: http://www.yageo.com/documents/recent/PYu-RC_Group_51_RoHS_L_9.pdf?src-supplier=Digi-Key; ComponentLink6URL: http://www.yageo.com/documents/recent/PYu-RC_Group_51_RoHS_L_9.pdf?src-supplier=Digi-Key; ComponentLink7Description: http://www.yageo.com/pdf/PYu-RC0805_51_RoHS_L_4.pdf?src-supplier=Avnet; ComponentLink7URL: http://www.yageo.com/pdf/PYu-RC0805_51_RoHS_L_4.pdf?src-supplier=Avnet; ComponentLink8Description: http://www.yageo.com/documents/recent/PYu-RC0805_51_RoHS_L_5.pdf?src-supplier=Avnet+Europe; ComponentLink8URL: http://www.yageo.com/documents/recent/PYu-RC0805_51_RoHS_L_5.pdf?src-supplier=Avnet+Europe; ComponentLink9Description: http://www.farnell.com/datasheets/442941.pdf?src-supplier=Element14; ComponentLink9URL: http://www.farnell.com/datasheets/442941.pdf?src-supplier=Element14; ComponentLink10Description: http://www.yageo.ru/pdf/RC0805.pdf?src-supplier=Future+Electronics; ComponentLink10URL: http://www.yageo.ru/pdf/RC0805.pdf?src-supplier=Future+Electronics; ComponentLink11Description: http://www.farnell.com/datasheets/2167052.pdf?src-supplier=Newark; ComponentLink11URL: http://www.farnell.com/datasheets/2167052.pdf?src-supplier=Newark; ComponentLink12Description: http://www.farnell.com/datasheets/444164.pdf?src-supplier=Element14; ComponentLink12URL: http://www.farnell.com/datasheets/444164.pdf?src-supplier=Element14; Construction: Rectangular; Contact Plating: Tin,Matte,Lead; Depth: 1.25mm; Features: Moisture Resistant; Lead Free: Lead Free; Length: 2mm; Library Name: res.SchLib; Manufacturer Part Number 1: RC0805JR-0722RL; Max Operating Temperature: 155°C; Military Standard: Not; Min Operating Temperature: -55°C; Number of Terminations: 2; Packaging: Tape and Reel; Power Rating: 125mW; Pricing 1: 1=0,10000, 10=0,03600, 100=0,01450, 1000=0,00652, 2500=0,00566 (USD); Radiation Hardening: No; REACH SVHC: Unknown; Resistance Tolerance: 5%; Resistor Type: Thick Film; RoHS: Yes; Series: RC; Size Code: 0805; Stock 1: 938847; Supplier 1: Digi-Key; Supplier Part Number 1: 311-22ARCT-ND; Termination: SMD/SMT; Tolerance: 5%
U3 = ATSAM4S8BA-AU: MICROCONTROLLER MCU, 32 BIT, CORTEX-M4, 120MHZ, LQFP-64; Architecture:ARM Cortex-M4; CPU Speed:120MHz; Program Memory Size:512KB; RAM Memory Size:128KB; No. of Pins:64; MCU Case Style:LQFP; No. of I/Os:47; Supply Voltage Min:1.62V ;RoHS Compliant: Yes; Manufacturer 1: Microchip / Atmel; Data Bus Width: 32b; Interface: I2C,SPI,USB,IrDA,MMC,UART,USART; Max Frequency: 32MHz; Max Supply Voltage: 3.6V; Memory Size: 512KB; Memory Type: FLASH,ROM; Min Supply Voltage: 1.62V; Number of ADC Channels: 11; Number of I2C Channels: 2; Number of I/Os: 47; Number of PWM Channels: 4; Number of SPI Channels: 1; Oscillator Type: Internal; Peripherals: Brown-out Detect/Reset,POR,PWM,WDT,DMA; RAM Size: 128KB; Case/Package: LQFP; Component Kind: Standard; ComponentLink1Description: https://datasheet.ciiva.com/12272/doc11100-52688-12272160.pdf?src-supplier=Mouser; ComponentLink1URL: https://datasheet.ciiva.com/12272/doc11100-52688-12272160.pdf?src-supplier=Mouser; ComponentLink2Description: https://datasheet.ciiva.com/30476/0900766b811a941e-30476054.pdf?src-supplier=RSComponents; ComponentLink2URL: https://datasheet.ciiva.com/30476/0900766b811a941e-30476054.pdf?src-supplier=RSComponents; ComponentLink3Description: https://datasheet.ciiva.com/8010/1653605-8010699.pdf?src-supplier=Element14; ComponentLink3URL: https://datasheet.ciiva.com/8010/1653605-8010699.pdf?src-supplier=Element14; Frequency: 120MHz; Height: 1.6mm; Lead Free: Lead Free; Length: 12mm; Library Name: ic.SchLib; Manufacturer Part Number 1: ATSAM4S8BA-AU; Max Operating Temperature: 85°C; Min Operating Temperature: -40°C; Mount: Surface Mount; Number of Pins: 64; Number of Timers/Counters: 2; Number of UART Channels: 2; Number of USB Channels: 1; Package Quantity: 160; Packaging: Tray; Radiation Hardening: No; REACH SVHC: No SVHC; RoHS: Yes; RoHS Compliant: Yes; Series: SAM4S; Stock 1: 0; Supplier 1: Future Electronics; Supplier Part Number 1: ATSAM4S8BA-AU-TRAY; Watchdog Timer: Yes; Width: 10mm
U4 = 10067847-001RLF: Conn; Data; SD (SanDisk Memory) Card Reader; Push - Push Type; Manufacturer 1: Amphenol ICC; Component Kind: Standard; ComponentLink1Description: http://www.farnell.com/cad/1912985.pdf?src-supplier=Farnell; ComponentLink1URL: http://www.farnell.com/cad/1912985.pdf?src-supplier=Farnell; ComponentLink2Description: http://www.farnell.com/cad/1912985.pdf?src-supplier=Element14; ComponentLink2URL: http://www.farnell.com/cad/1912985.pdf?src-supplier=Element14; ComponentLink3Description: http://www.farnell.com/datasheets/2562281.pdf?src-supplier=Newark; ComponentLink3URL: http://www.farnell.com/datasheets/2562281.pdf?src-supplier=Newark; ComponentLink4Description: aa; ComponentLink4URL: https://www.amphenol-icc.com/media/wysiwyg/files/drawing/10067847.pdf; Manufacturer Part Number 1: 10067847-001RLF; RoHS: Yes; RoHS Compliant: Yes; Stock 1: 0; Supplier 1: Allied Electronics; Supplier Part Number 1: 70248486; Library Name: conectors.SchLib
U5 = ISL21010CFH330Z-TK: INTERSIL         ISL21010CFH330Z-TK             Voltage Reference, Precision Micropower, Series - Fixed, ISL21010 Series, 3V, SOT-23-3; Max Input Voltage: 6.5V; Max Output Current: 25mA; Max Supply Current: 100uA; Max Supply Voltage: 5.5V; Number of Channels: 1; Quiescent Current: 80uA; Reference Type: Series,Fixed; Temperature Coefficient: 50ppm/°C; Tolerance: 0.2%; Case/Package: SOT-23; Component Kind: Standard; ComponentLink1Description: https://datasheet.ciiva.com/1172/1599533-1172856.pdf?src-supplier=Element14; ComponentLink1URL: https://datasheet.ciiva.com/1172/1599533-1172856.pdf?src-supplier=Element14; Height: 0.91mm; Length: 2.92mm; Library Name: ic.SchLib; Manufacturer 1: Intersil; Manufacturer Part Number 1: ISL21010CFH330Z-TK; Max Operating Temperature: 125°C; Min Operating Temperature: -40°C; Mount: Surface Mount; Number of Pins: 3; Output Current: 25mA; Output Voltage: 3V; Package Quantity: 1000; Packaging: Tape and Reel; Pricing 1: 2000=0,81760, 4000=0,80080, 6000=0,78400, 10000=0,76720, 20000=0,75040, 100000=0,73360, 200000=0,71680 (USD); Radiation Hardening: No; REACH SVHC: No SVHC; Reference Voltage: 3V; RoHS: Yes; RoHS Compliant: Yes; Series: ISL21010CFH330; Stock 1: 0; Supplier 1: Avnet; Supplier Part Number 1: ISL21010CFH330Z-TK; Width: 1.3mm
U9 = UX60SC-MB-5S8: Conn Mini USB 2.0 Type B RCP 5 POS 0.8mm Solder RA SMD 5 Terminal 1 Port Embossed T/R; Manufacturer 1: Hirose; Connector Type: USB,Receptacle; Current Rating: 1A; Gender: Receptacle; Insulation Resistance: 100MR; Max Voltage Rating (AC): 5V; Number of Contacts: 5; Number of Ports: 1; USB Standard: USB 2.0; Component Kind: Standard; ComponentLink1Description: https://datasheet.ciiva.com/22666/1693469-22666928.pdf?src-supplier=Element14; ComponentLink1URL: https://datasheet.ciiva.com/22666/1693469-22666928.pdf?src-supplier=Element14; ComponentLink2Description: https://datasheet.ciiva.com/4598/ed-ux-20140717-4598805.pdf?src-supplier=Mouser; ComponentLink2URL: https://datasheet.ciiva.com/4598/ed-ux-20140717-4598805.pdf?src-supplier=Mouser; ComponentLink3Description: https://datasheet.ciiva.com/15181/getdatasheetpartid-457316-15181367.pdf?src-supplier=Verical; ComponentLink3URL: https://datasheet.ciiva.com/15181/getdatasheetpartid-457316-15181367.pdf?src-supplier=Verical; Contact Material: Copper Alloy,Copper; Contact Plating: Gold; Depth: 7mm; Lead Free: Lead Free; Length: 7.7mm; Manufacturer Part Number 1: UX60SC-MB-5S8; Max Current Rating: 1A; Max Operating Temperature: 75°C; Min Operating Temperature: -30°C; Mount: Surface Mount,Horizontal,Through Hole; Number of Positions: 5; Number of Rows: 1; Number of Terminals/Pins: 5; Orientation: Right Angle; Package Quantity: 1000; Packaging: Tape and Reel; Pitch: 0.8mm; Pricing 1: 1=0,99000, 10=0,86700, 25=0,81600, 50=0,78200, 100=0,74800, 250=0,68000, 500=0,61200 (USD); REACH SVHC: No SVHC; RoHS: Yes; Series: UX60S; Stock 1: 15275; Supplier 1: Digi-Key; Supplier Part Number 1: H11589CT-ND; Termination: Solder; Library Name: conectors.SchLib
U10 = 1074: Strain Relief Bushing; .437" Mounting Hole; Black; Manufacturer 1: Keystone Electronics; Component Kind: Standard; Manufacturer Part Number 1: 1074; Pricing 1: 1=0,66000, 25=0,57280, 100=0,46150, 250=0,42480, 500=0,39892, 1000=0,37305, 2500=0,34070, 5000=0,31483 (USD); RoHS: No; Stock 1: 5773; Supplier 1: Digi-Key; Supplier Part Number 1: 36-1074-ND; Library Name: conectors.SchLib
U15 = 1040310811: 1.10mm Pitch microSD Memory Card Connector, Surface Mount, Push-Pull Type, 1.42mm Height, with Detect Switch; Manufacturer 1: Molex; Current Rating: 500mA; Gender: Female; Mating Cycles: 10000; Number of Circuits: 8; Pitch: 1.1mm; Voltage Rating (AC): 10V; Component Kind: Standard; ComponentLink1Description: https://datasheet.ciiva.com/16655/1040310811-memory-card-socket-16655292.pdf?src-supplier=Avnet+Europe; ComponentLink1URL: https://datasheet.ciiva.com/16655/1040310811-memory-card-socket-16655292.pdf?src-supplier=Avnet+Europe; ComponentLink2Description: https://datasheet.ciiva.com/4581/1040310811-memory-card-socket-153593-4581012.pdf?src-supplier=Mouser; ComponentLink2URL: https://datasheet.ciiva.com/4581/1040310811-memory-card-socket-153593-4581012.pdf?src-supplier=Mouser; ComponentLink3Description: https://datasheet.ciiva.com/683/1040310811-sd-683579.pdf?src-supplier=Digi-Key; ComponentLink3URL: https://datasheet.ciiva.com/683/1040310811-sd-683579.pdf?src-supplier=Digi-Key; ComponentLink4Description: https://datasheet.ciiva.com/3121/1040310811-memory-card-socket-3121437.pdf?src-supplier=Avnet; ComponentLink4URL: https://datasheet.ciiva.com/3121/1040310811-memory-card-socket-3121437.pdf?src-supplier=Avnet; ComponentLink5Description: https://datasheet.ciiva.com/15174/getdatasheetpartid-716154-15174887.pdf?src-supplier=Verical; ComponentLink5URL: https://datasheet.ciiva.com/15174/getdatasheetpartid-716154-15174887.pdf?src-supplier=Verical; ComponentLink6Description: https://datasheet.ciiva.com/16496/1040310811-memory-card-socket-16496702.pdf?src-supplier=Avnet+Asia; ComponentLink6URL: https://datasheet.ciiva.com/16496/1040310811-memory-card-socket-16496702.pdf?src-supplier=Avnet+Asia; ComponentLink7Description: https://datasheet.ciiva.com/683/1040310811-sd-683580.pdf?src-supplier=Digi-Key; ComponentLink7URL: https://datasheet.ciiva.com/683/1040310811-sd-683580.pdf?src-supplier=Digi-Key; ComponentLink8Description: https://datasheet.ciiva.com/683/1040310811-sd-683581.pdf?src-supplier=Digi-Key; ComponentLink8URL: https://datasheet.ciiva.com/683/1040310811-sd-683581.pdf?src-supplier=Digi-Key; Connector Type: Connector; Contact Material: Bronze; Contact Plating: Gold; Depth: 11.4mm; Halogen Free: Low Halogen; Height: 1.42mm; Housing Material: Polymer; Length: 11.14mm; Library Name: others.SchLib; Manufacturer Part Number 1: 1040310811; Max Operating Temperature: 85°C; Min Operating Temperature: -25°C; Mount: Standard,Surface Mount; Number of Contacts: 8; Number of Rows: 1; Orientation: Right Angle; Package Quantity: 1; Packaging: Tape and Reel; Pricing 1: 1=2,12000, 10=1,80700, 100=1,49270, 1000=1,17842 (USD); Radiation Hardening: No; REACH SVHC: Unknown; RoHS: Yes; Series: 104031; Stock 1: 24019; Supplier 1: Digi-Key; Supplier Part Number 1: WM6357CT-ND; Termination: Solder; Width: 11.4mm
Y1 = ECS-.327-12.5-34S-TR: Crystal 0.032768MHz ±20ppm (Tol) 12.5pF Flexural 70000Ohm 2-Pin CSMD T/R; Manufacturer 1: ECS International; ESR (Equivalent Series Resistance): 70kR; Case/Package: SMD/SMT; Component Kind: Standard; ComponentLink1Description: https://datasheet.ciiva.com/15047/ecx-34s-15047020.pdf?src-supplier=Digi-Key; ComponentLink1URL: https://datasheet.ciiva.com/15047/ecx-34s-15047020.pdf?src-supplier=Digi-Key; ComponentLink2Description: https://datasheet.ciiva.com/15047/ecx-34s-15047021.pdf?src-supplier=Digi-Key; ComponentLink2URL: https://datasheet.ciiva.com/15047/ecx-34s-15047021.pdf?src-supplier=Digi-Key; ComponentLink3Description: https://datasheet.ciiva.com/15047/ecx-34s-15047022.pdf?src-supplier=Digi-Key; ComponentLink3URL: https://datasheet.ciiva.com/15047/ecx-34s-15047022.pdf?src-supplier=Digi-Key; Frequency Tolerance: 20ppm; Height: 0.035inch; Lead Free: Lead Free; Lead Pitch: 1.8mm; Length: 0.126inch; Library Name: others.SchLib; Load Capacitance: 12.5pF; Manufacturer Part Number 1: ECS-.327-12.5-34S-TR; Max Operating Temperature: 125°C; Min Operating Temperature: -40°C; Mount: Surface Mount; Number of Pins: 2; Package Quantity: 3000; Packaging: Tape and Reel; Pricing 1: 1=1,37000, 10=1,21400, 50=1,13520, 100=1,00320, 500=0,95040, 1000=0,79200 (USD); RoHS: Yes; RoHS Compliant: Yes; Series: ECX-34S; Stock 1: 0; Supplier 1: Digi-Key; Supplier Part Number 1: XC1967CT-ND; Termination: SMD/SMT; Tolerance: 20ppm; Width: 1.5mm
Y2 = ECS-120-20-3X-TR: CRYSTAL 12.0000MHZ 20PF SMD; Manufacturer 1: ECS International; ESR (Equivalent Series Resistance): 60R; Frequency Stability: 50ppm; Frequency Tolerance: 30ppm; Component Kind: Standard; ComponentLink1Description: https://datasheet.ciiva.com/852/csm-3x-852331.pdf?src-supplier=Digi-Key; ComponentLink1URL: https://datasheet.ciiva.com/852/csm-3x-852331.pdf?src-supplier=Digi-Key; ComponentLink2Description: https://datasheet.ciiva.com/852/csm-3x-852332.pdf?src-supplier=Digi-Key; ComponentLink2URL: https://datasheet.ciiva.com/852/csm-3x-852332.pdf?src-supplier=Digi-Key; ComponentLink3Description: https://datasheet.ciiva.com/852/csm-3x-852333.pdf?src-supplier=Digi-Key; ComponentLink3URL: https://datasheet.ciiva.com/852/csm-3x-852333.pdf?src-supplier=Digi-Key; Height: 0.091inch; Lead Free: Lead Free; Length: 0.276inch; Library Name: others.SchLib; Load Capacitance: 20pF; Manufacturer Part Number 1: ECS-120-20-3X-TR; Max Operating Temperature: 70°C; Min Operating Temperature: -10°C; Mount: Surface Mount; Number of Pins: 2; Package Quantity: 1; Packaging: Tape and Reel; Pricing 1: 1=0,34000, 10=0,28800, 50=0,25880, 100=0,23000, 500=0,21850, 1000=0,18400 (USD); RoHS: Yes; Series: CSM-3X; Stock 1: 16869; Supplier 1: Digi-Key; Supplier Part Number 1: XC1770CT-ND; Termination: SMD/SMT; Tolerance: 30ppm; Width: 4.1mm
H1 H2 = header 1x2: Component Kind: Standard; Library Name: conectors.SchLib
U12 = esp8266 12f: Component Kind: Standard; Library Name: ic.SchLib
